# Altium schematic sheet RCD300_AMPLIFIER_P1.SchDoc
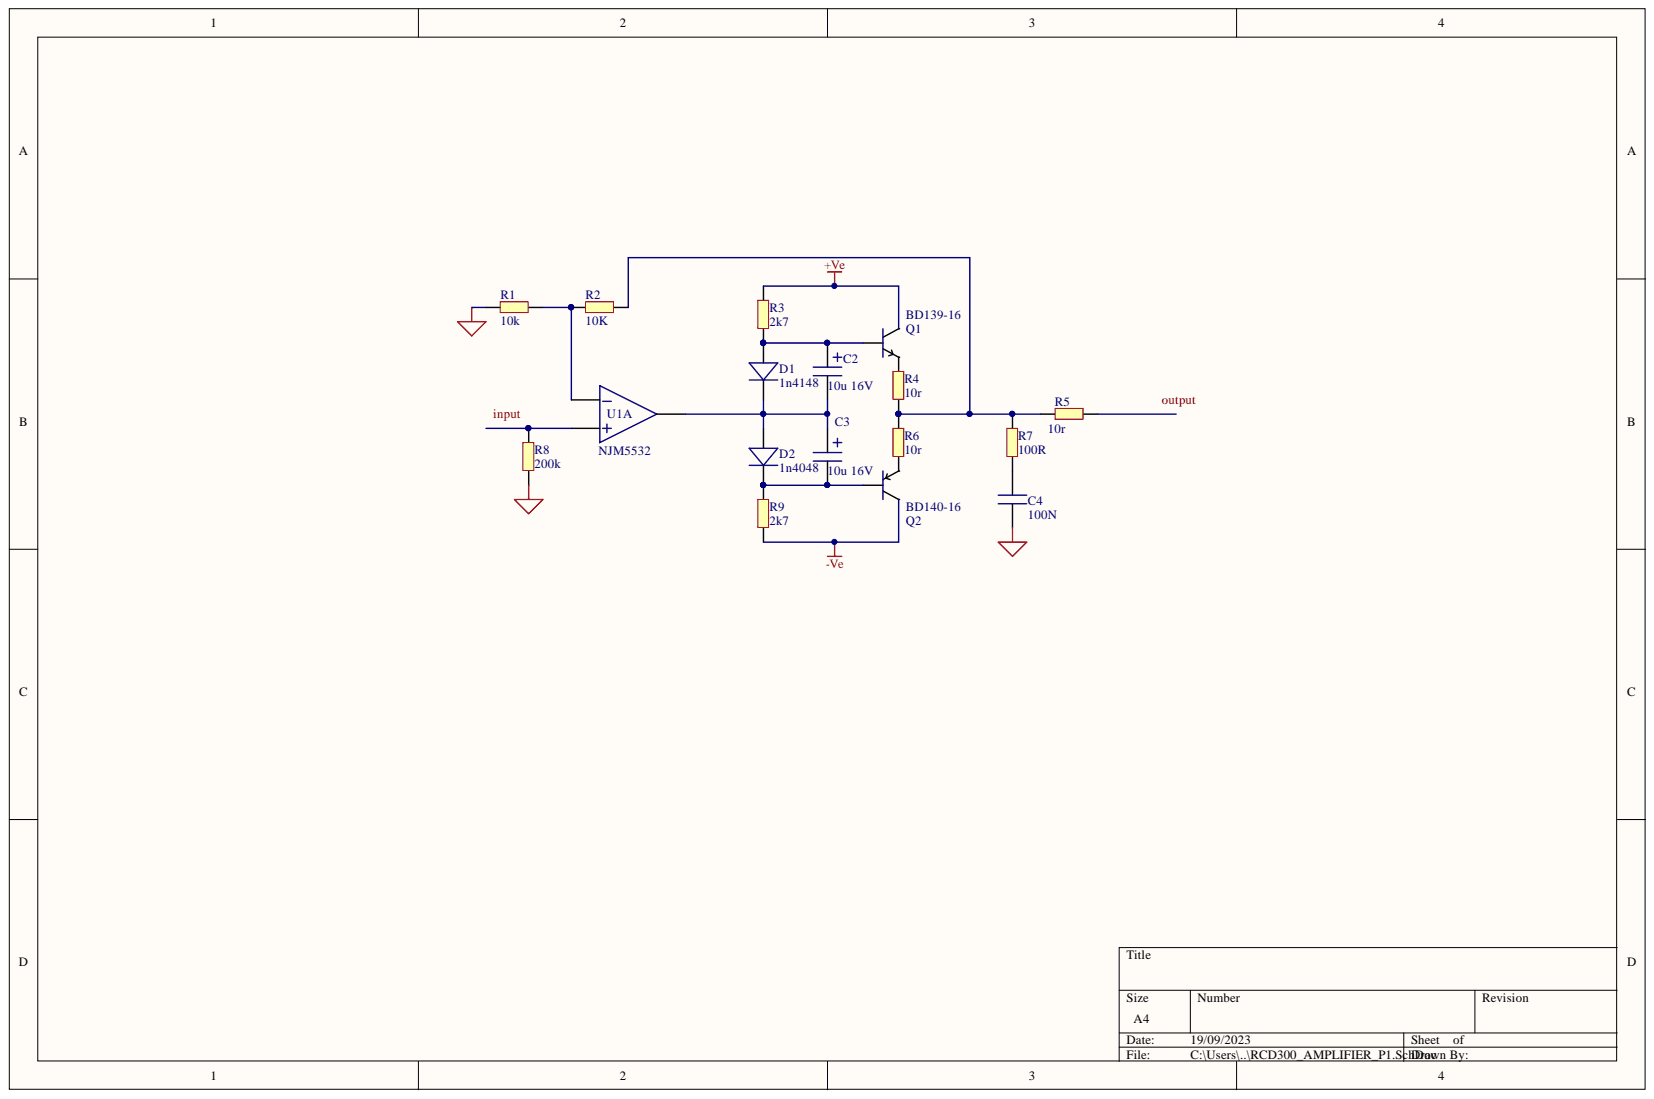
[diagram p0: RCD300_AMPLIFIER_P1.SchDoc]

## Parts
C2  10u 16V  [CAP CASE B]
C3  10u 16V  [CAP CASE B]
C4  100N  [0805]
D1  1n4148  [SOT-23]
D2  1n4048  [SOT-23]
Q1  BD139-16  [TO-126-V]
Q2  BD140-16  [TO-126-V]
R1  10k  [0805]
R2  10K  [0805]
R3  2k7  [0805]
R4  10r  [1206]
R5  10r  [1206]
R6  10r  [1206]
R7  100R  [0805]
R8  200k  [0805]
R9  2k7  [0805]
U1  NJM5532  [DIP-8 SOCKETED]  (part A)

## Nets
+VE: Q1.2 R3.2
-VE: Q2.2 R9.1
SGND: C4.1 R1.1 R8.1
NetC2_1: C2.1 D1.1 Q1.3 R3.1
NetC2_2: C2.2 C3.1 D1.3 D2.1 U1.1
NetC3_2: C3.2 D2.3 Q2.3 R9.2
NetC4_2: C4.2 R7.1
NetQ1_1: Q1.1 R4.1
NetQ2_1: Q2.1 R6.2
NetR1_2: R1.2 R2.1 U1.2
NetR2_2: R2.2 R4.2 R5.1 R6.1 R7.2
NetR8_2: R8.2 U1.3
INPUT:
OUTPUT:

Not connected: R5 2

## Library data of the parts
C2 C3 = 10u 16V: Standard Case B Electrolytic Capacitor; Type: Al; UA P/N: 16-0026; Voltage: 16; Component Kind: Standard; Library Name: SMD Capacitors.DbLib; Value: 10u 16V
C4 = 100N: Standard 0805 Capacitor; Type: X7R; UA P/N: 16-0001; Voltage: 50V; Component Kind: Standard; Library Name: SMD Capacitors.DbLib; Value: 100N
D1 D2 = BAS21: SOT23 Diode; Type: SIG DIODE; UA P/N: 15-0007; Value: BAS21; Component Kind: Standard; Library Name: SMD Discretes.DbLib
Q1 = BD139-16: NPN TO126 Transistor; Type: BD139; Component Kind: Standard; Farnell PN: 108-4508; Library Name: TH Discretes.DbLib; Value: BD139-16
Q2 = BD140-16: PNP TO126 Transistor; Type: BD140; Component Kind: Standard; Farnell PN: 108-4566; Library Name: TH Discretes.DbLib; Value: BD140-16
R1 = 3k9: Standard 0805 Resistor; UA P/N: 13-0053; Value: 3K9; Component Kind: Standard; Library Name: SMD Resistors.DbLib; Type: THICK FILM
R2 = 10K: Standard 0805 Resistor; UA P/N: 13-0007; Component Kind: Standard; Library Name: SMD Resistors.DbLib; Type: THICK FILM; Value: 10K
R3 R9 = 4K7: Standard 0805 Resistor; UA P/N: 13-0060; Value: 4K7; Component Kind: Standard; Library Name: SMD Resistors.DbLib; Type: THICK FILM
R4-R6 = 1206 4R7: Standard 1206 Resistor; UA P/N: 13-0083; Component Kind: Standard; Library Name: SMD Resistors.DbLib; Type: Thick Film; Value: 1206 4R7
R7 = 100R: Standard 0805 Resistor; UA P/N: 13-0004; Component Kind: Standard; Library Name: SMD Resistors.DbLib; Type: THICK FILM; Value: 100R
R8 = 100K: Standard 0805 Resistor; UA P/N: 13-0002; Value: 100K; Component Kind: Standard; Library Name: SMD Resistors.DbLib; Type: THICK FILM
U1 = NJM5532: Dual OPAMP DIP8 socketed; UA P/N: 15-1046; Component Kind: Standard; Type: BIPOLAR OP-AMP DUAL; Value: NJM5532; Library Name: TH IC Analogue.DbLib
